# Altium schematic sheet TPVSI_Inverter_Current_Sensing.SchDoc(TPVSI_Inverter_Current_Sensing)
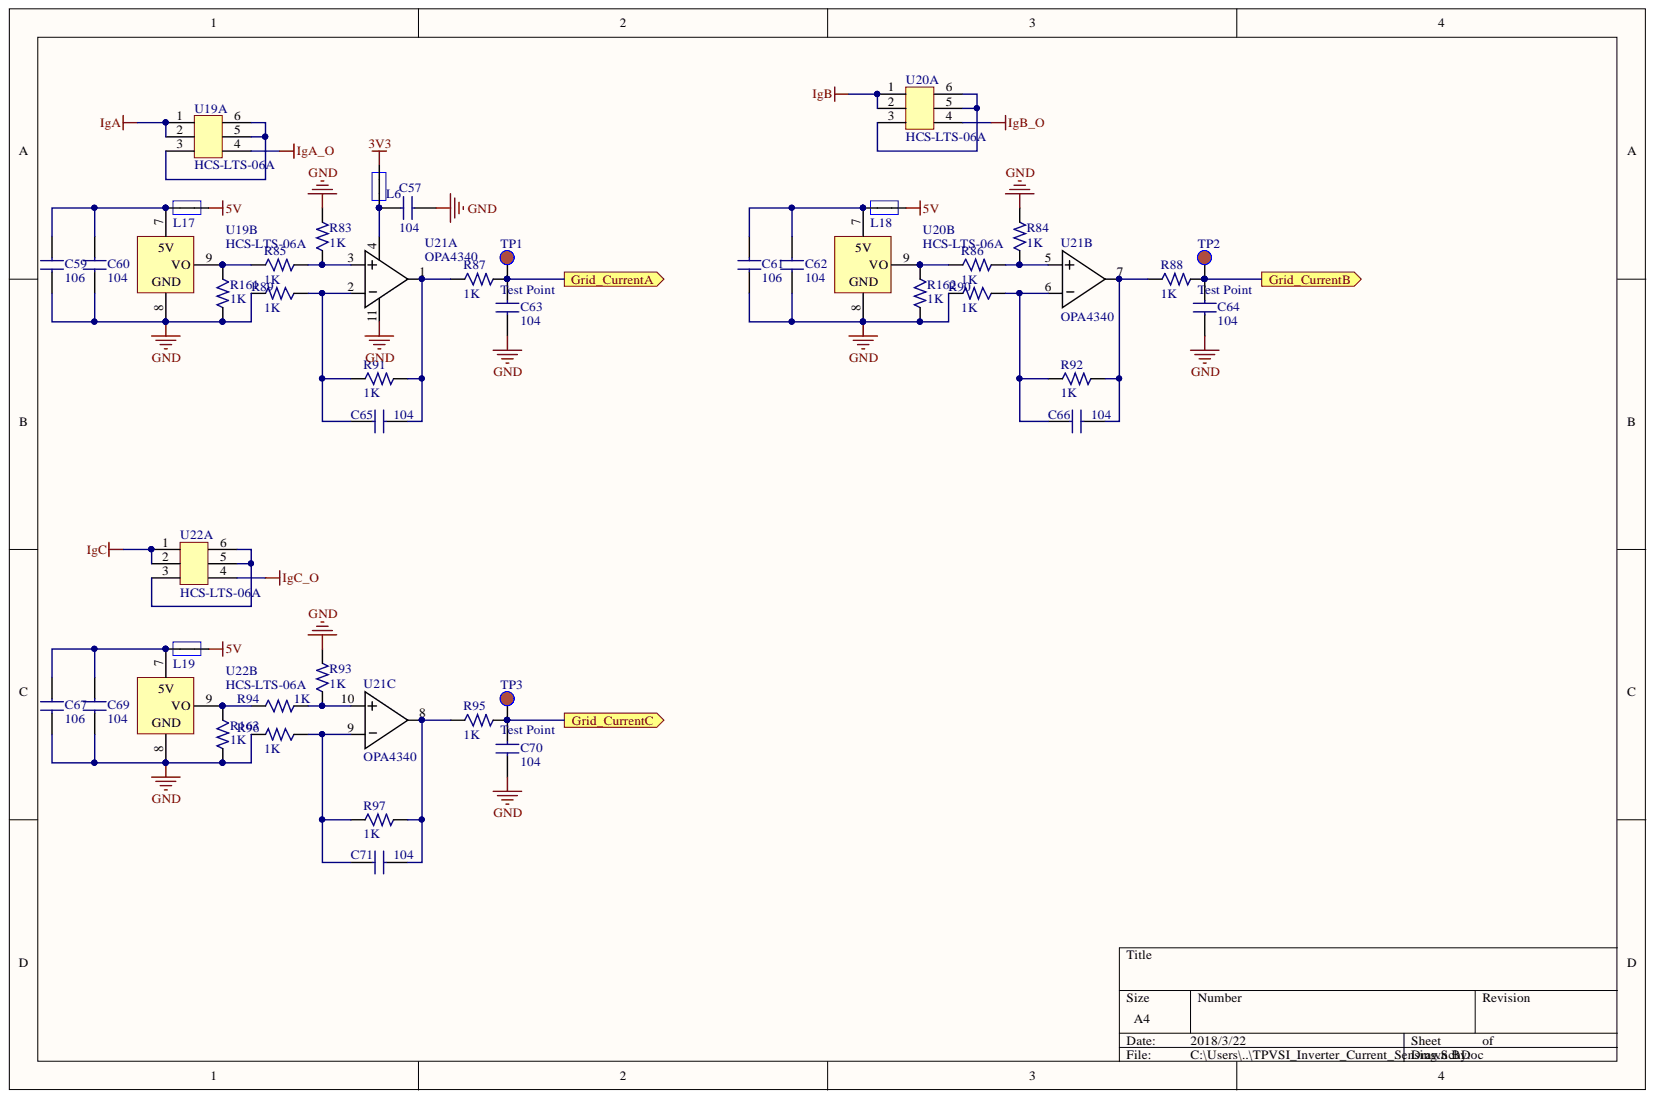
[diagram p0: TPVSI_Inverter_Current_Sensing.SchDoc]

## Parts
C57  104  [C-RAD-0603]
C59  106  [C-RAD-0603]
C60  104  [C-RAD-0603]
C61  106  [C-RAD-0603]
C62  104  [C-RAD-0603]
C63  104  [C-RAD-0603]
C64  104  [C-RAD-0603]
C65  104  [C-RAD-0603]
C66  104  [C-RAD-0603]
C67  106  [C-RAD-0603]
C69  104  [C-RAD-0603]
C70  104  [C-RAD-0603]
C71  104  [C-RAD-0603]
L6  Inductor_Small  [1206]  (value not drawn)
L17  Inductor_Small  [1206]  (value not drawn)
L18  Inductor_Small  [1206]  (value not drawn)
L19  Inductor_Small  [1206]  (value not drawn)
R83  1K  [0603_1]
R84  1K  [0603_1]
R85  1K  [0603_1]
R86  1K  [0603_1]
R87  1K  [0603_1]
R88  1K  [0603_1]
R89  1K  [0603_1]
R90  1K  [0603_1]
R91  1K  [0603_1]
R92  1K  [0603_1]
R93  1K  [0603_1]
R94  1K  [0603_1]
R95  1K  [0603_1]
R96  1K  [0603_1]
R97  1K  [0603_1]
R161  1K  [0603_1]
R162  1K  [0603_1]
R163  1K  [0603_1]
TP1  Test Point  [TestPointOrange]
TP2  Test Point  [TestPointOrange]
TP3  Test Point  [TestPointOrange]
U19  HCS-LTS-06A  [HCS-LTS]  (parts A,B)
U20  HCS-LTS-06A  [HCS-LTS]  (parts A,B)
U21  OPA4340  [SOP-14N]  (parts A,B,C)
U22  HCS-LTS-06A  [HCS-LTS]  (parts A,B)

## Ports
Grid_CurrentA, Grid_CurrentB, Grid_CurrentC

## Nets
3V3: L6.2
5V: L17.1 L18.1 L19.1
GND: C57.2 C59.1 C60.1 C61.1 C62.1 C63.1 C64.1 C67.1 C69.1 C70.1 R83.2 R84.2 R89.2 R90.2 R93.2 R96.2 R161.2 R162.2 R163.2 U19.8 U20.8 U21.11 U22.8
IgA: U19.1 U19.2
IgA_O: U19.4
IgB: U20.1 U20.2
IgB_O: U20.4
IgC: U22.1 U22.2
IgC_O: U22.4
Grid_CurrentA: C63.2 R87.2 TP1.1 ; port Grid_CurrentA
Grid_CurrentB: C64.2 R88.2 TP2.1 ; port Grid_CurrentB
Grid_CurrentC: C70.2 R95.2 TP3.1 ; port Grid_CurrentC
NetC57_1: C57.1 L6.1 U21.4
NetC59_2: C59.2 C60.2 L17.2 U19.7(5V)
NetC61_2: C61.2 C62.2 L18.2 U20.7(5V)
NetC65_1: C65.1 R87.1 R91.2 U21.1
NetC65_2: C65.2 R89.1 R91.1 U21.2
NetC66_1: C66.1 R88.1 R92.2 U21.7
NetC66_2: C66.2 R90.1 R92.1 U21.6
NetC67_2: C67.2 C69.2 L19.2 U22.7(5V)
NetC71_1: C71.1 R95.1 R97.2 U21.8
NetC71_2: C71.2 R96.1 R97.1 U21.9
NetR83_1: R83.1 R85.2 U21.3
NetR84_1: R84.1 R86.2 U21.5
NetR85_1: R85.1 R161.1 U19.9(VO)
NetR86_1: R86.1 R162.1 U20.9(VO)
NetR93_1: R93.1 R94.2 U21.10
NetR94_1: R94.1 R163.1 U22.9(VO)
NetU19_3: U19.3 U19.5 U19.6
NetU20_3: U20.3 U20.5 U20.6
NetU22_3: U22.3 U22.5 U22.6

## Library data of the parts
C57 C59-C67 C69-C71 = cap: Component Kind: Standard; Library Name: Chu_16.IntLib
R83-R97 R161-R163 = Res: Component Kind: Standard; Library Name: Chu_16.IntLib
TP1-TP3 = TP1: 测试点; Component Kind: Standard; Library Name: Chu_16.IntLib
L6 L17-L19 = Inductor_Small: Component Kind: Standard; Library Name: Chu_16.IntLib
U19 U20 U22 = HCS-LTS-06A: Component Kind: Standard; Library Name: Chu_16.IntLib
U21 = OPA4340: Component Kind: Standard; Library Name: Chu_16.IntLib
